# Altium schematic sheet Main.SchDoc
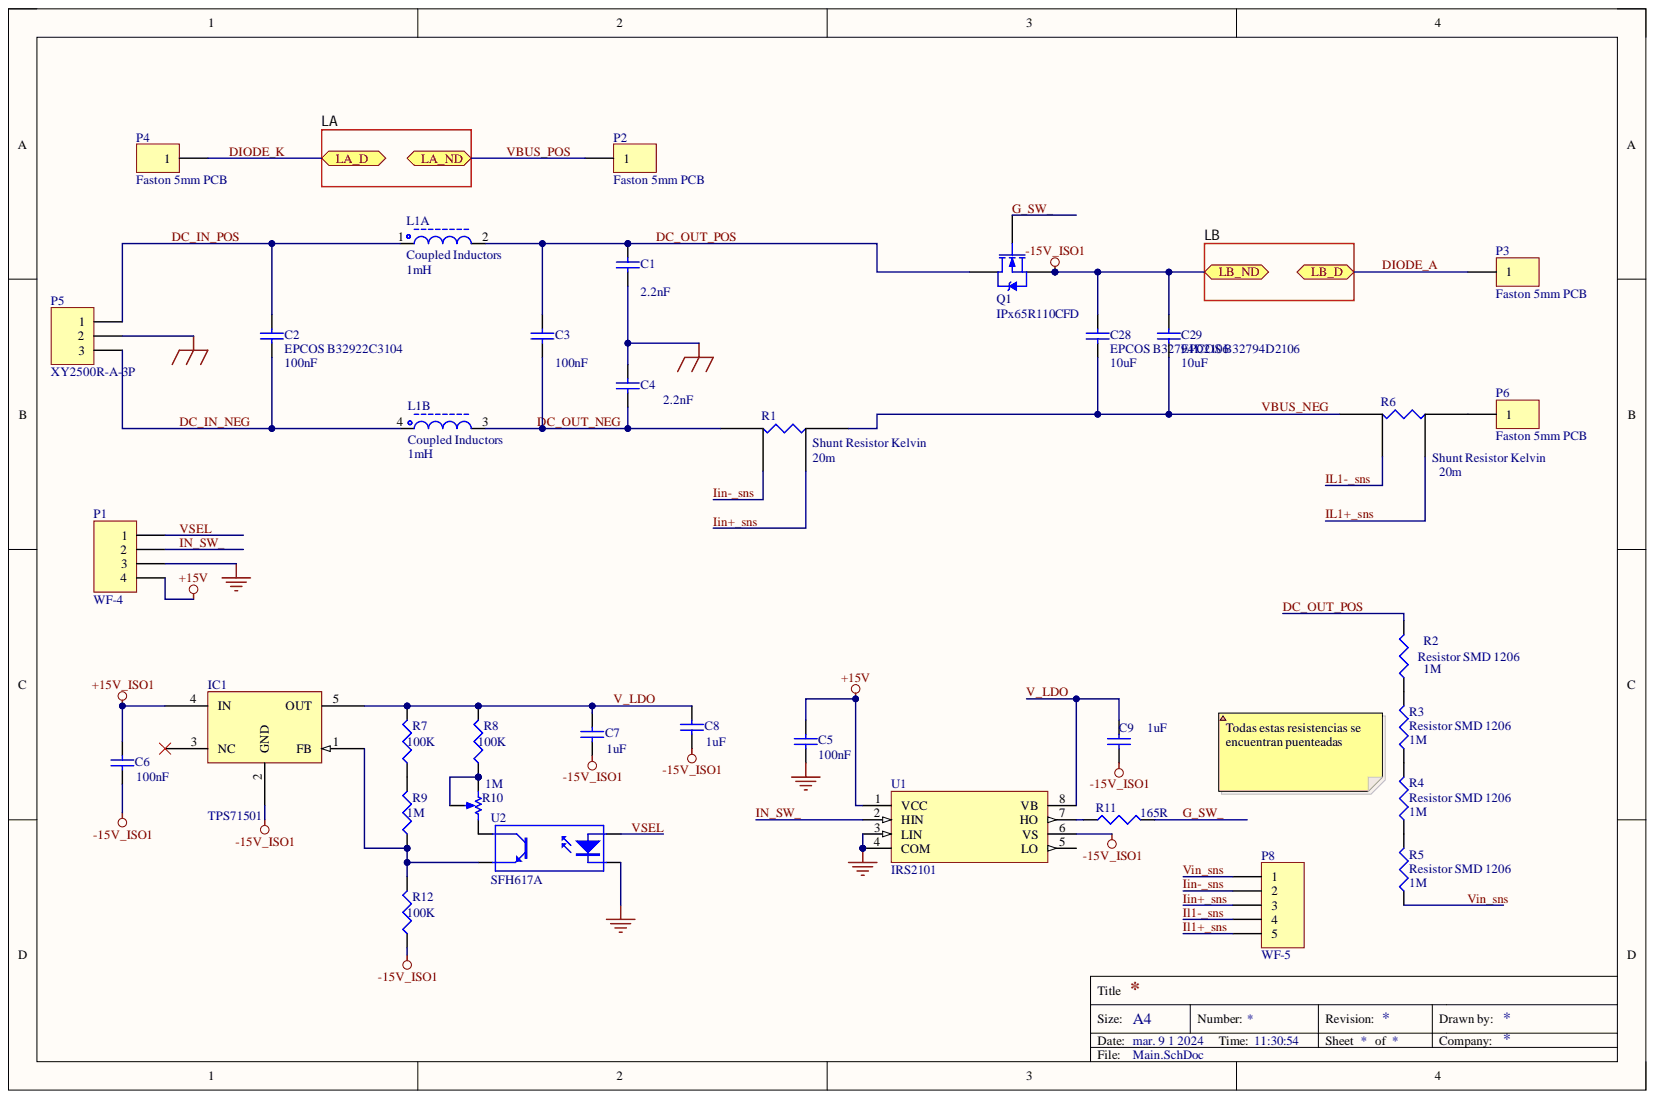
[diagram p0: Main.SchDoc]

## Parts
C1  value 2.2nF  [SAFETY Y1 type]  (hidden comment: TDK CD12-E2GA222MYNS)
C2  EPCOS B32922C3104  value 100nF  [MKP PITCH:15mm SIZE:5mmx18mm]
C3  EPCOS B32922C3104  value 100nF  [MKP PITCH:15mm SIZE:5mmx18mm]
C4  value 2.2nF  [SAFETY Y1 type]  (hidden comment: TDK CD12-E2GA222MYNS)
C5  value 100nF  [Chip2PinSM127P600-8N]  (hidden comment: EPCOS C2012X7R1H104K)
C6  value 100nF  [Chip2PinSM127P600-8N]  (hidden comment: EPCOS C2012X7R1H104K)
C7  value 1uF  [CAPC3216X18N 1206]  (hidden comment: ETHER C3216X7R1H105K)
C8  value 1uF  [CAPC3216X18N 1206]  (hidden comment: ETHER C3216X7R1H105K)
C9  value 1uF  [CAPC3216X18N 1206]  (hidden comment: ETHER C3216X7R1H105K)
C28  EPCOS B32794D2106  value 10uF  [MKP AC filtering - 10]
C29  EPCOS B32794D2106  value 10uF  [MKP AC filtering - 10]
IC1  TPS71501  [TSOP65P210X110-5N]
L1  Coupled Inductors  value 1mH  [Bourns 8108-RC]  (parts A,B)
P1  WF-4  [HDR1X4]
P2  Faston 5mm PCB  [FASTON 5mm PCB]
P3  Faston 5mm PCB  [FASTON 5mm PCB]
P4  Faston 5mm PCB  [FASTON 5mm PCB]
P5  XY2500R-A-3P  [XY2500R-A-3P]
P6  Faston 5mm PCB  [FASTON 5mm PCB]
P8  WF-5  [HDR1X5]
Q1  IPx65R110CFD  [TO-247 Horiz. Heatsink]
R1  Shunt Resistor Kelvin  value 20m  [Chip2PinSM127P600-8N]
R2  Resistor SMD 1206  value 1M  [RESC3116X07N - 1206]
R3  Resistor SMD 1206  value 1M  [RESC3116X07N - 1206]
R4  Resistor SMD 1206  value 1M  [RESC3116X07N - 1206]
R5  Resistor SMD 1206  value 1M  [RESC3116X07N - 1206]
R6  Shunt Resistor Kelvin  value 20m  [Chip2PinSM127P600-8N]
R7  Resistor SMD 1206  value 100K  [RESC3116X07N - 1206]
R8  Resistor SMD 1206  value 100K  [RESC3116X07N - 1206]
R9  Resistor SMD 1206  value 1M  [RESC3116X07N - 1206]
R10  value 1M  [Variable Resistor Horizontal]  (hidden comment: Bourns 3386)
R11  value 165R  [Chip2PinSM127P600-8N - 0805]  (hidden comment: Resistor SMD 0805)
R12  Resistor SMD 1206  value 100K  [RESC3116X07N - 1206]
U1  IRS2101  [SOIC127P600X175-8N]
U2  SFH617A  [DIP4]

## Ports
LA_D, LA_ND, LB_D, LB_ND

## Nets
+15V: C5.2 P1.4 U1.1(VCC)
+15V_ISO1: C6.2 IC1.4(IN)
EARTH: C1.1 C4.2 P5.2
PGND: C5.1 P1.3 U1.3(LIN) U1.4(COM) U2.2
-15V_ISO1: C6.1 C7.1 C8.1 C9.1 C28.2 C29.2 IC1.2(GND) Q1.3 R12.1 U1.6(VS) ; port LB_ND
DC_IN_NEG: C2.1 L1.4 P5.3
DC_IN_POS: C2.2 L1.1 P5.1
DC_OUT_NEG: C3.1 C4.1 L1.3 R1.4
DC_OUT_POS: C1.2 C3.2 L1.2 Q1.2 R2.2
DIODE_A: P3.1 ; port LB_D
DIODE_K: P4.1 ; port LA_D
G_SW_: Q1.1 R11.2
Iin+_sns: P8.3 R1.2
Iin-_sns: P8.2 R1.3
Il1+_sns: P8.5 R6.2
Il1-_sns: P8.4 R6.3
IN_SW_: P1.2 U1.2(HIN)
V_LDO: C7.2 C8.2 C9.2 IC1.5(OUT) R7.2 R8.2 U1.8(VB)
VBUS_NEG: C28.1 C29.1 R1.1 R6.4
VBUS_POS: P2.1 ; port LA_ND
Vin_sns: P8.1 R5.1
VSEL: P1.1 U2.1
NetIC1_1: IC1.1(FB) R9.1 R12.2 U2.3
NetP6_1: P6.1 R6.1
NetR2_1: R2.1 R3.2
NetR3_1: R3.1 R4.2
NetR4_1: R4.1 R5.2
NetR7_1: R7.1 R9.2
NetR8_1: R8.1 R10.1 R10.2
NetR10_3: R10.3 U2.4
NetR11_1: R11.1 U1.7(HO)

Not connected: IC1 3(NC); U1 5(LO)

## Library data of the parts
C1 C4 = TDK CD12-E2GA222MYNS: Safety Standard Certified Ceramic Y1 2.2nF 250Vac; Component Kind: Standard; LatestRevisionDate: 17-Jul-2002; LatestRevisionNote: Re-released for DXP Platform.; Library Name: Capacitor.SchLib; PackageDescription: Radial Cap, Thru-Hole; 2 Leads; 0.3 in Pin Spacing; PackageReference: RAD-0.3; Published: 8-Jun-2000; Signal Integrity: Cap; Simulation: CAP; Publisher: Altium Limited
C2 C3 = EPCOS B32922C3104: MKP EMI suppression X2 100nF 305Vac; Component Kind: Standard; LatestRevisionDate: 17-Jul-2002; LatestRevisionNote: Re-released for DXP Platform.; Library Name: Capacitor.SchLib; PackageDescription: Radial Cap, Thru-Hole; 2 Leads; 0.3 in Pin Spacing; PackageReference: RAD-0.3; Published: 8-Jun-2000; Signal Integrity: Cap; Simulation: CAP; Publisher: Altium Limited
C5 C6 = EPCOS C2012X7R1H104K: Ceramic Multilayer 100nF 50Vdc X7R; Component Kind: Standard; LatestRevisionDate: 17-Jul-2002; LatestRevisionNote: Re-released for DXP Platform.; Library Name: Capacitor.SchLib; PackageDescription: Radial Cap, Thru-Hole; 2 Leads; 0.3 in Pin Spacing; PackageReference: RAD-0.3; Published: 8-Jun-2000; Signal Integrity: Cap; Simulation: CAP; Publisher: Altium Limited
C7-C9 = ETHER C3216X7R1H105K: Ceramic Multilayer 1uF 50Vdc X7R; Component Kind: Standard; LatestRevisionDate: 17-Jul-2002; LatestRevisionNote: Re-released for DXP Platform.; Library Name: Capacitor.SchLib; PackageDescription: Radial Cap, Thru-Hole; 2 Leads; 0.3 in Pin Spacing; PackageReference: RAD-0.3; Published: 8-Jun-2000; Signal Integrity: Cap; Simulation: CAP; Publisher: Altium Limited
C28 C29 = EPCOS B32794D2106: MKP AC Filtering 10uF 630Vdc; Component Kind: Standard; LatestRevisionDate: 17-Jul-2002; LatestRevisionNote: Re-released for DXP Platform.; Library Name: Capacitor.SchLib; PackageDescription: Radial Cap, Thru-Hole; 2 Leads; 0.3 in Pin Spacing; PackageReference: RAD-0.3; Published: 8-Jun-2000; Signal Integrity: Cap; Simulation: CAP; Publisher: Altium Limited
IC1 = TPS71501: LDO 50 mA, 24 V, 3.2-µA Supply Current; Component Kind: Standard; Library Name: Linear Voltage Regulator.SchLib
L1 = Common mode filter 10A: Bourns Common mode inductor 10A 1mH 8108-RC; Code_IEC: 1005; Code_JEITA: 0402; Component Kind: Standard; LatestRevisionDate: 29-May-2009; LatestRevisionNote: IPC-7351 Footprint Added.; Library Name: Inductor.SchLib; PackageDescription: Chip Inductor; PackageReference: 0402-A; Published: 8-Jun-2000; Signal Integrity: Inductor; Simulation: INDUCTOR; Publisher: Altium Limited
P1 = Header 4: Header, 4-Pin, 2.54mm pitch; System: Connector; Component Kind: Standard; LatestRevisionDate: 17-Jul-2002; LatestRevisionNote: Re-released for DXP Platform.; Library Name: Connector.SchLib; Signal Integrity: Connector; Publisher: Altium Limited
P2-P4 P6 = Faston 5mm PCB: System: Connector; Component Kind: Standard; Description: Faston 5mm PCB; LatestRevisionDate: 17-Jul-2002; LatestRevisionNote: Re-released for DXP Platform.; Library Name: Connector.SchLib; Signal Integrity: Connector; Publisher: Altium Limited
P5 = XY2500R-A-3P: HEADER 3P 90gra P/CI P=5MM; System: Connector; Component Kind: Standard; LatestRevisionDate: 17-Jul-2002; LatestRevisionNote: Re-released for DXP Platform.; Library Name: Connector.SchLib; Signal Integrity: Connector; Publisher: Altium Limited
P8 = Header 5: Header, 5-Pin, 2.54mm pitch; System: Connector; Component Kind: Standard; LatestRevisionDate: 17-Jul-2002; LatestRevisionNote: Re-released for DXP Platform.; Library Name: Connector.SchLib; Signal Integrity: Connector; Publisher: Altium Limited
Q1 = IPx65R110CFD: Infineon Power MOSFET N-Channel 650V 31.2A; Component Kind: Standard; ComponentLink1Description: Manufacturer Link; ComponentLink1URL: http://www.irf.com/; ComponentLink2Description: Datasheet; ComponentLink2URL: http://www.irf.com/product-info/datasheets/data/IRHN7150.pdf; DatasheetVersion: 13-Jul-2001; Library Name: MOSFET.SchLib; PackageDescription: SM; 3 Leadless Terminals; Body 10.28 x 7.64 x 3.06 mm (LxWxH); PackageReference: SMD-0.5; PackageVersion: 16-Sep-2002; Published: 17-Sep-2003; Publisher: Altium Limited
R10 = Bourns 3386 Horizontal 1-Turn: Trimming Potentiometer Horizontal 1-Turn 10%; Set Position: 0.5; Sim Note: Enter the Resistance value and the set point into parameter fields.; Component Kind: Standard; LatestRevisionDate: 17-Jul-2002; LatestRevisionNote: Re-released for DXP Platform.; Library Name: Resistor.SchLib; PackageDescription: Variable Resistor, Thru-Hole; 3 Leads; PackageReference: VR5; Published: 8-Jun-2000; Publisher: Altium Limited
U1 = IRS2101: High and Low Side Driver IRF; Note: Pins 4, 5, 9, 10 and 16 are not connected; Code_IPC: SOIC127P1030-16; Code_JEDEC: MS-013-AA; Component Kind: Standard; ComponentLink1Description: Manufacturer Link; ComponentLink1URL: http://www.irf.com/; ComponentLink2Description: Datasheet; ComponentLink2URL: http://www.irf.com/product-info/datasheets/data/ir2112.pdf; DatasheetVersion: PD60026J; LatestRevisionDate: 13-Apr-2006; LatestRevisionNote: IPC-7351 Footprint Added.; Library Name: Gate Driver.SchLib; PackageDescription: 16-Pin Small Outline Integrated Circuit 1.27 mm Pitch; PackageReference: SOIC16; Published: 8-Jun-2000; Publisher: Altium Limited
U2 = SFH617A: Phototransistor Output, High Reliability,  5300 VRMS, 110 °C Rated; Component Kind: Standard; DatasheetVersion: Nov-2003; LatestRevisionDate: 04-Mar-2005; LatestRevisionNote: Sch pins 3 & 4 Swapped.; Library Name: Optocoupler.SchLib; PackageReference: DIP-4; Published: 24-Mar-1999; Publisher: Altium Limited
R1 R6 = Shunt Resistor Kelvin: Component Kind: Standard; Description: Shunt Resistor Kelvin; LatestRevisionDate: 29-May-2009; LatestRevisionNote: IPC-7351 Footprint Added.; Library Name: Current Sensor.SchLib; PackageDescription: Chip Resistor; PackageReference: J1-0603; Published: 8-Jun-2000; Signal Integrity: Res; Simulation: RESISTOR; Publisher: Altium Limited
R2-R5 R7-R9 R12 = Resistor SMD 1206: Component Kind: Standard; Description: Resistor SMD 1206; LatestRevisionDate: 29-May-2009; LatestRevisionNote: IPC-7351 Footprint Added.; Library Name: Resistor.SchLib; PackageDescription: Chip Resistor; PackageReference: J1-0603; Published: 8-Jun-2000; Signal Integrity: Res; Simulation: RESISTOR; Publisher: Altium Limited
R11 = Resistor SMD 0805: Component Kind: Standard; Description: Resistor SMD 0805; LatestRevisionDate: 29-May-2009; LatestRevisionNote: IPC-7351 Footprint Added.; Library Name: Resistor.SchLib; PackageDescription: Chip Resistor; PackageReference: J1-0603; Published: 8-Jun-2000; Signal Integrity: Res; Simulation: RESISTOR; Publisher: Altium Limited
